# Altium schematic sheet NTC and RH Sensor.SchDoc("NTC and RH Sensor")
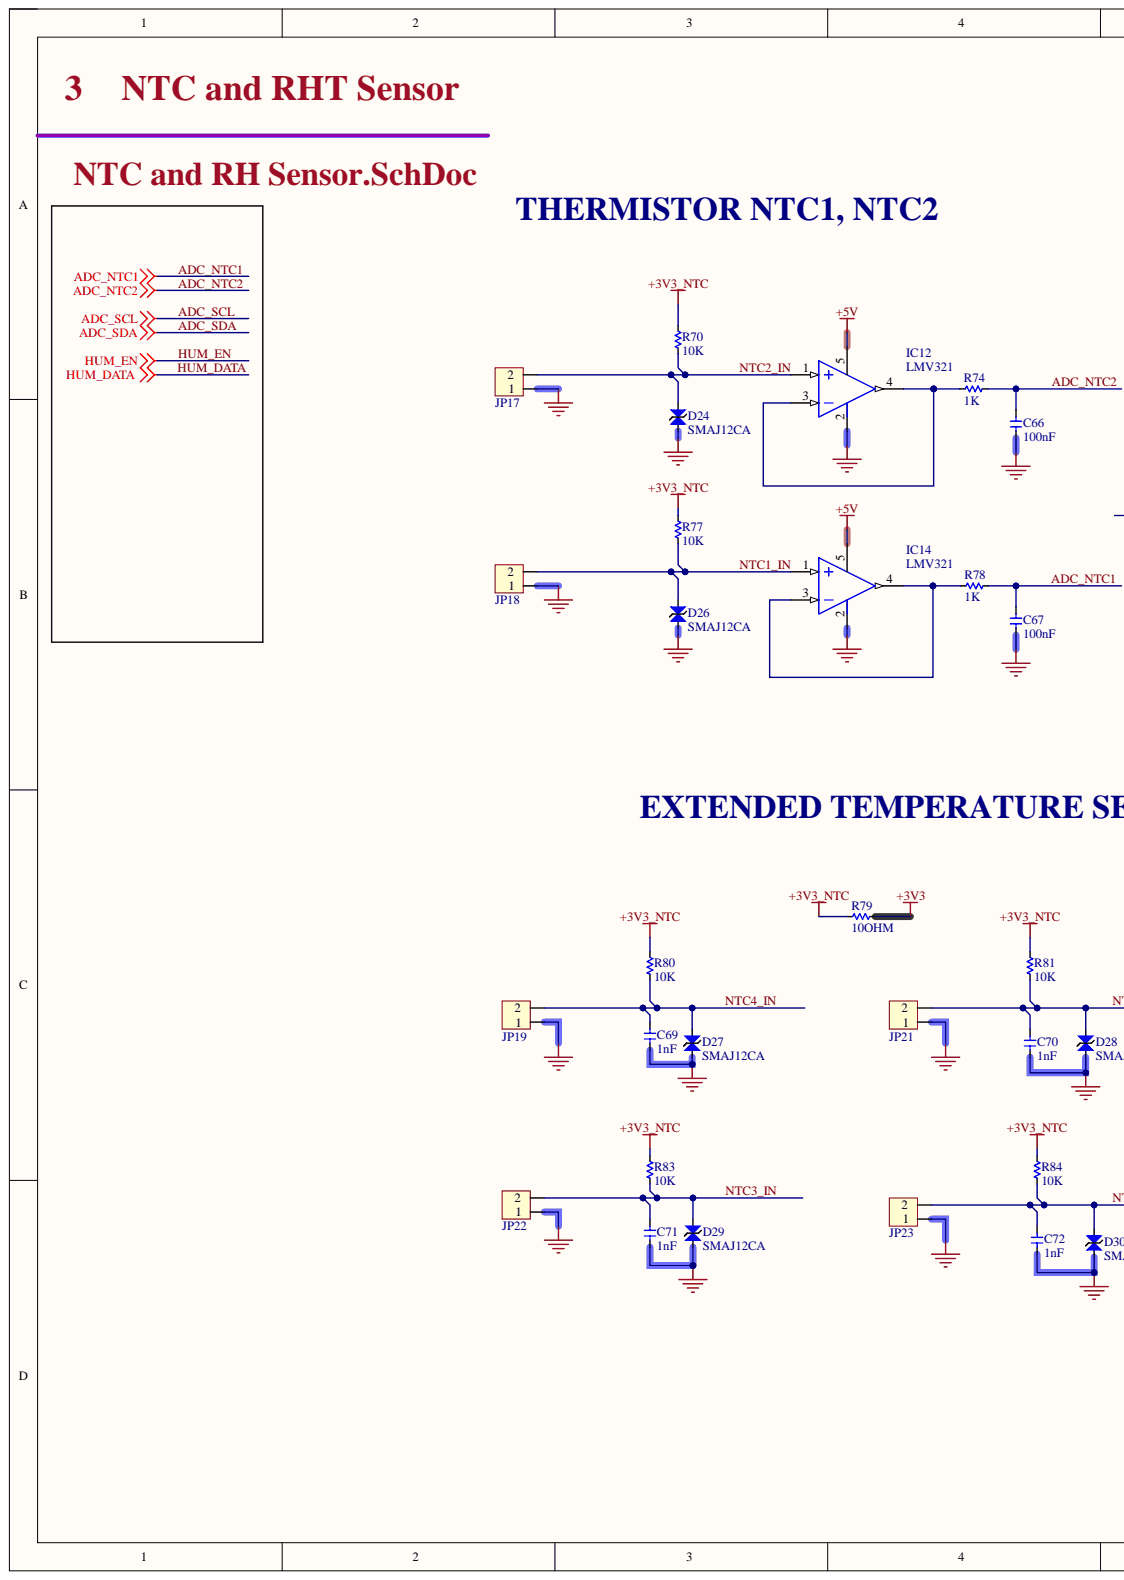
[diagram p0: NTC and RH Sensor.SchDoc, left]
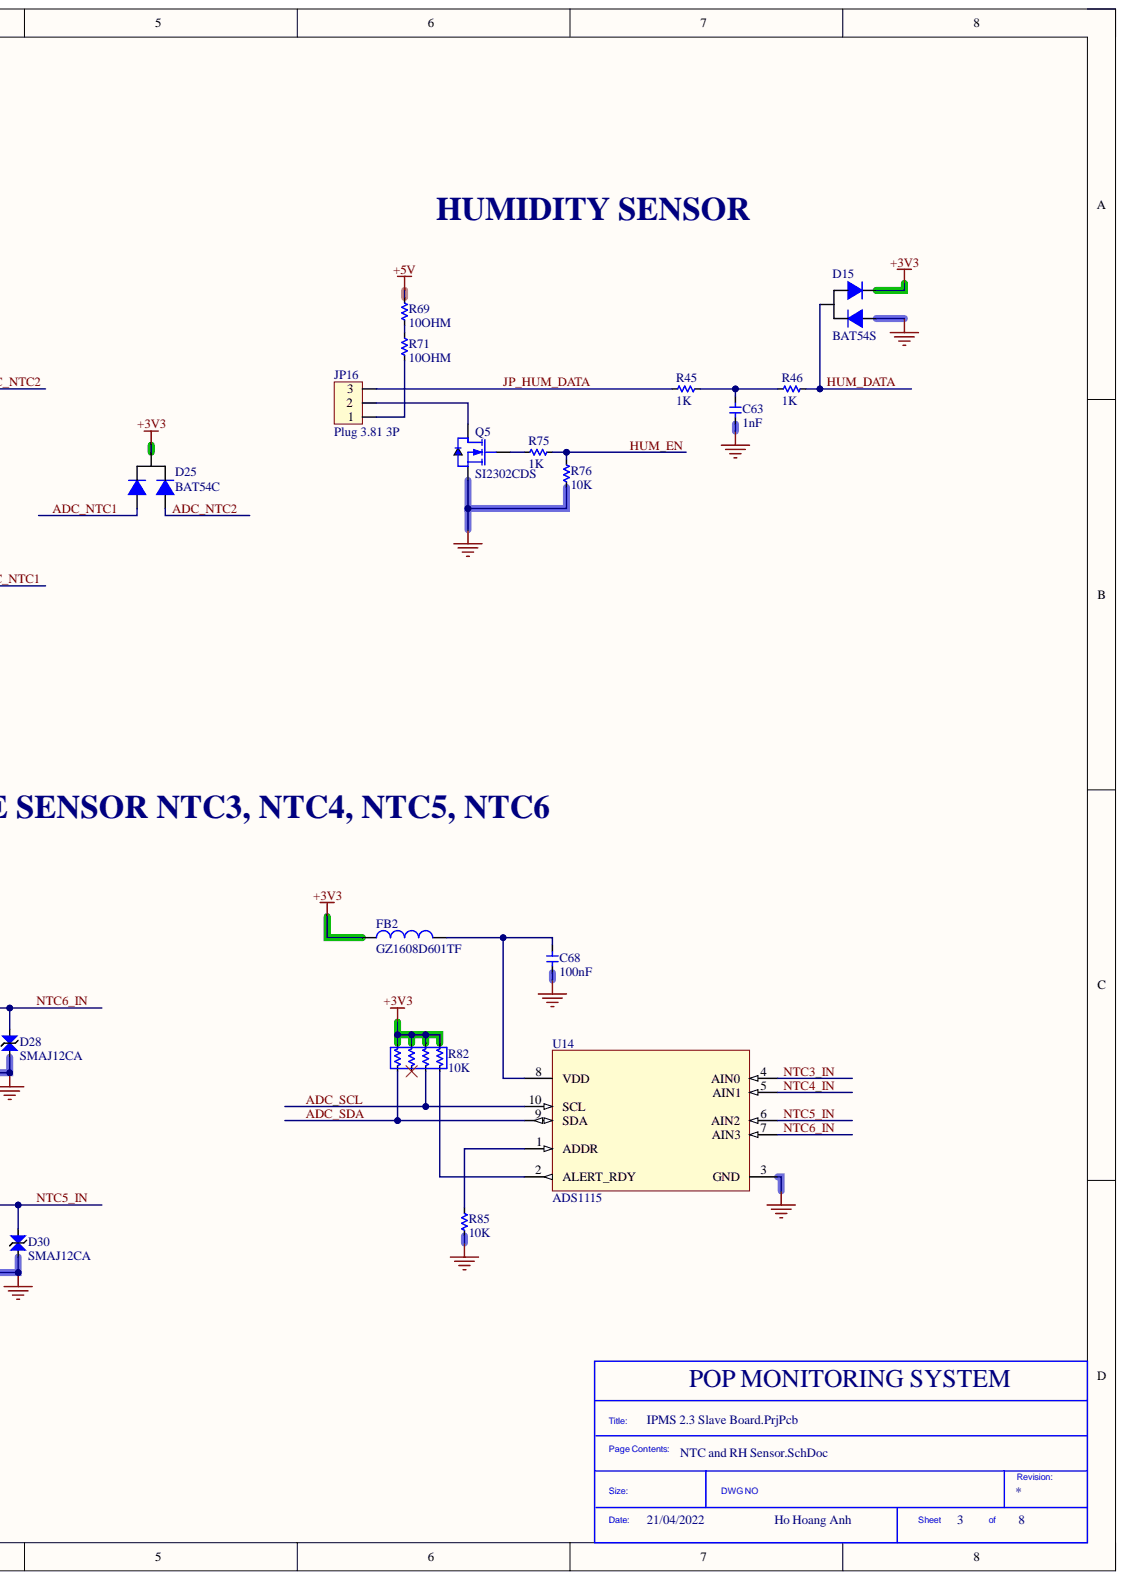
[diagram p1: NTC and RH Sensor.SchDoc, right]

## Parts
C63  1nF  [C_0603]
C66  100nF  [C_0603]
C67  100nF  [C_0603]
C68  100nF  [C_0603]
C69  1nF  [C_0603]
C70  1nF  [C_0603]
C71  1nF  [C_0603]
C72  1nF  [C_0603]
D15  BAT54S  [SOT-23-3]
D24  SMAJ12CA  [SMA_L4.4-W2.6-LS5.0-BI]
D25  BAT54C  [SOT-23-3]
D26  SMAJ12CA  [SMA_L4.4-W2.6-LS5.0-BI]
D27  SMAJ12CA  [SMA_L4.4-W2.6-LS5.0-BI]
D28  SMAJ12CA  [SMA_L4.4-W2.6-LS5.0-BI]
D29  SMAJ12CA  [SMA_L4.4-W2.6-LS5.0-BI]
D30  SMAJ12CA  [SMA_L4.4-W2.6-LS5.0-BI]
FB2  GZ1608D601TF  [L_0603]
IC12  LMV321  [SOT-23-5]
IC14  LMV321  [SOT-23-5]
JP16  Plug 3.81 3P  [CONN-TH_1803439]
JP17  Plug 3.81 2P  [CONN-TH_1803426]  (value not drawn)
JP18  Plug 3.81 2P  [CONN-TH_1803426]  (value not drawn)
JP19  Plug 3.81 2P  [CONN-TH_1803426]  (value not drawn)
JP21  Plug 3.81 2P  [CONN-TH_1803426]  (value not drawn)
JP22  Plug 3.81 2P  [CONN-TH_1803426]  (value not drawn)
JP23  Plug 3.81 2P  [CONN-TH_1803426]  (value not drawn)
Q5  SI2302CDS  [SOT-23-3]
R45  1K  [R_0603]
R46  1K  [R_0603]
R69  10OHM  [R_0805]
R70  10K  [R_0805]
R71  10OHM  [R_0805]
R74  1K  [R_0603]
R75  1K  [R_0603]
R76  10K  [R_0603]
R77  10K  [R_0805]
R78  1K  [R_0603]
R79  10OHM  [R_0805]
R80  10K  [R_0805]
R81  10K  [R_0805]
R82  10K  [R_0603_x4]
R83  10K  [R_0805]
R84  10K  [R_0805]
R85  10K  [R_0603]
U14  ADS1115  [MSOP-10_L3.0-W3.0-P0.50-LS5.0-BL]

## Nets
+3V3: D15.2 D25.3 FB2.1 R79.1 R82.1 R82.2 R82.3 R82.4
+3V3_NTC: R70.1 R77.1 R79.2 R80.1 R81.1 R83.1 R84.1
+5V: IC12.5 IC14.5 R69.1
GND: C63.1 C66.1 C67.1 C68.1 C69.1 C70.1 C71.1 C72.1 D15.1 D24.1 D26.1 D27.1 D28.1 D29.1 D30.1 IC12.2 IC14.2 JP17.1 JP18.1 JP19.1 JP21.1 JP22.1 JP23.1 Q5.2 R76.2 R85.2 U14.3(GND)
ADC_NTC1: C67.2 D25.2 R78.1
ADC_NTC2: C66.2 D25.1 R74.1
ADC_SCL: R82.7 U14.10(SCL)
ADC_SDA: R82.5 U14.9(SDA)
HUM_DATA: D15.3 R46.1
HUM_EN: R75.1 R76.1
JP_HUM_DATA: JP16.3 R45.2
NTC1_IN: D26.2 IC14.1 JP18.2 R77.2
NTC2_IN: D24.2 IC12.1 JP17.2 R70.2
NTC3_IN: C71.2 D29.2 JP22.2 R83.2 U14.4(AIN0)
NTC4_IN: C69.2 D27.2 JP19.2 R80.2 U14.5(AIN1)
NTC5_IN: C72.2 D30.2 JP23.2 R84.2 U14.6(AIN2)
NTC6_IN: C70.2 D28.2 JP21.2 R81.2 U14.7(AIN3)
NetC63_2: C63.2 R45.1 R46.2
NetC68_2: C68.2 FB2.2 U14.8(VDD)
NetIC12_3: IC12.3 IC12.4 R74.2
NetIC14_3: IC14.3 IC14.4 R78.2
NetJP16_1: JP16.1 R71.2
NetJP16_2: JP16.2 Q5.3
NetQ5_1: Q5.1 R75.2
NetR69_2: R69.2 R71.1
NetR82_8: R82.8 U14.2(ALERT_RDY)
NetR85_1: R85.1 U14.1(ADDR)

Not connected: R82 6

## Library data of the parts
C63 C69-C72 = CL10B102KB8NNNC: 1NF 50V 0603 ROHS; Manufacturer: Samsung Electro-Mechanics; Assembly Type: SMT Assembly; Component Kind: Standard; LCSC Part Number: C1588; Manufacturer Part Number: CL10B102KB8NNNC; Package: 0603; Library Name: IPMS 2.3 Slave Board.SCHLIB
C66-C68 = CC0603KRX7R9BB104: 100nF 50V X7R ±10% 0603 Multilayer Ceramic Capacitors MLCC - SMD/SMT ROHS; Manufacturer: YAGEO; Assembly Type: SMT Assembly; Component Kind: Standard; LCSC Part Number: C14663; Manufacturer Part Number: CC0603KRX7R9BB104; Package: 0603; Library Name: IPMS 2.3 Slave Board.SCHLIB
D15 = BAT54S-7-F: 30V 800mV@100mA 200mA SOT-23(SOT-23-3) Schottky Barrier Diodes (SBD) ROHS; Assembly Type: SMT Assembly; Component Kind: Standard; LCSC Part Number: C83574; Manufacturer: Diodes; Manufacturer Part Number: BAT54S-7-F; Package: SOT-23-3; Library Name: IPMS 2.3 Slave Board.SCHLIB
D24 D26-D30 = SMAJ12CA: TVS DIODE 12V 19.9V SMA; Manufacturer: MDD（Microdiode Electronics）; Assembly Type: SMT Assembly; Component Kind: Standard; LCSC Part Number: C110042; Manufacturer Part Number: SMAJ12CA; Package: SMA,DO-214AC; Library Name: IPMS 2.3 Slave Board.SCHLIB
D25 = BAT54C-7-F: DIODE ARRAY SCHOTTKY 30V SOT23-3; Manufacturer: Diodes; Assembly Type: SMT Assembly; Component Kind: Standard; LCSC Part Number: C134405; Manufacturer Part Number: BAT54C-7-F; Package: SOT-23-3; Library Name: IPMS 2.3 Slave Board.SCHLIB
FB2 = FB_GZ1608D601TF: 600Ω @ 100MHz 1 200mA 450mΩ 0603 Ferrite Beads ROHS; Manufacturer: Sunlord; Assembly Type: SMT Assembly; Component Kind: Standard; LCSC Part Number: C1002; Manufacturer Part Number: GZ1608D601TF; Package: 0603; Library Name: IPMS 2.3 Slave Board.SCHLIB
IC12 IC14 = LM321LVIDBVR: LM321 SOT-23-5 GENERAL PURPOSE AMPLIFIERS ROHS; Manufacturer: Texas Instruments; Assembly Type: SMT Assembly; Component Kind: Standard; LCSC Part Number: C471859; Manufacturer Part Number: LM321LVIDBVR; Package: SOT-23-5; Library Name: IPMS 2.3 Slave Board.SCHLIB
JP16 = 1803439: 160V 8A 3 Straight pin 1 3.81mm Green Board Edge/Receptacle P=3.81mm Pluggable System Terminal Block ROHS; Manufacturer: Phoenix Contact; Assembly Type: Hand Soldering; Component Kind: Standard; LCSC Part Number: C480525; Manufacturer Part Number: 1803439; Package: CONN-TH_1803439; Library Name: IPMS 2.3 Slave Board.SCHLIB
JP17-JP19 JP21-JP23 = 1803426: 160V 8A 2 Straight pin 1 3.81mm Green Board Edge/Receptacle P=3.81mm Pluggable System Terminal Block ROHS; Manufacturer: Phoenix Contact; Assembly Type: Hand Soldering; Component Kind: Standard; LCSC Part Number: C480514; Manufacturer Part Number: 1803426; Package: CONN-TH_1803426; Library Name: IPMS 2.3 Slave Board.SCHLIB
Q5 = SI2302CDS-T1-GE3: MOSFET N-CH 20V 2.6A SOT-23; Manufacturer: Vishay Siliconix; Assembly Type: SMT Assembly; Component Kind: Standard; LCSC Part Number: C10488; Manufacturer Part Number: Si2302CDS-T1-GE3; Package: SOT-23-3; Library Name: IPMS 2.3 Slave Board.SCHLIB
R45 R46 R74 R75 R78 = 0603WAF1001T5E: 1KOHMS ±1% 1/10W 0603 ROHS; Manufacturer: Uniroyal Elec; Assembly Type: SMT Assembly; Component Kind: Standard; LCSC Part Number: C21190; Manufacturer Part Number: 0603WAF1001T5E; Package: 0603; Library Name: IPMS 2.3 Slave Board.SCHLIB
R69 R71 R79 = 0805W8F100JT5E: 10OHMS ±1% 1/8W 0805 ROHS; Manufacturer: Uniroyal Elec; Assembly Type: SMT Assembly; Component Kind: Standard; LCSC Part Number: C17415; Manufacturer Part Number: 0805W8F100JT5E; Package: 0805; Library Name: IPMS 2.3 Slave Board.SCHLIB
R70 R77 R80 R81 R83 R84 = 0805W8F1002T5E: 10KOHMS ±1% 1/8W 0805 ROHS; Manufacturer: Uniroyal Elec; Assembly Type: SMT Assembly; Component Kind: Standard; LCSC Part Number: C17414; Manufacturer Part Number: 0805W8F1002T5E; Package: 0805; Library Name: IPMS 2.3 Slave Board.SCHLIB
R76 R85 = 0603WAF1002T5E: 10KOHMS ±1% 1/10W 0603 ROHS; Manufacturer: Uniroyal Elec; Assembly Type: SMT Assembly; Component Kind: Standard; LCSC Part Number: C25804; Manufacturer Part Number: 0603WAF1002T5E; Package: 0603; Library Name: IPMS 2.3 Slave Board.SCHLIB
R82 = 4D03WGJ0103T5E: 10KOHMS ±5% 1/16W 0603_X4 ROHS; Manufacturer: Uniroyal Elec; ComponentLink1 Description: Manufacturer; ComponentLink2 Description: Datasheet; Assembly Type: SMT Assembly; Component Kind: Standard; ComponentLink1URL: http://www.we-online.com; ComponentLink2URL: http://katalog.we-online.de/em/datasheet/6130xx21821.pdf; LCSC Part Number: C29718; Manufacturer Part Number: 4D03WGJ0103T5E; Package: 0603_x4; Library Name: IPMS 2.3 Slave Board.SCHLIB
U14 = ADS1115IDGSR: IC ADC 16BIT SIGMA-DELTA 10VSSOP; Manufacturer: Texas Instruments; Assembly Type: SMT Assembly; Component Kind: Standard; LCSC Part Number: C37593; Manufacturer Part Number: ADS1115IDGSR; Package: MSOP-10_3.0x3.0x0.5P; Library Name: IPMS 2.3 Slave Board.SCHLIB
